# Altium schematic sheet 3_USB_TO_UART.SchDoc
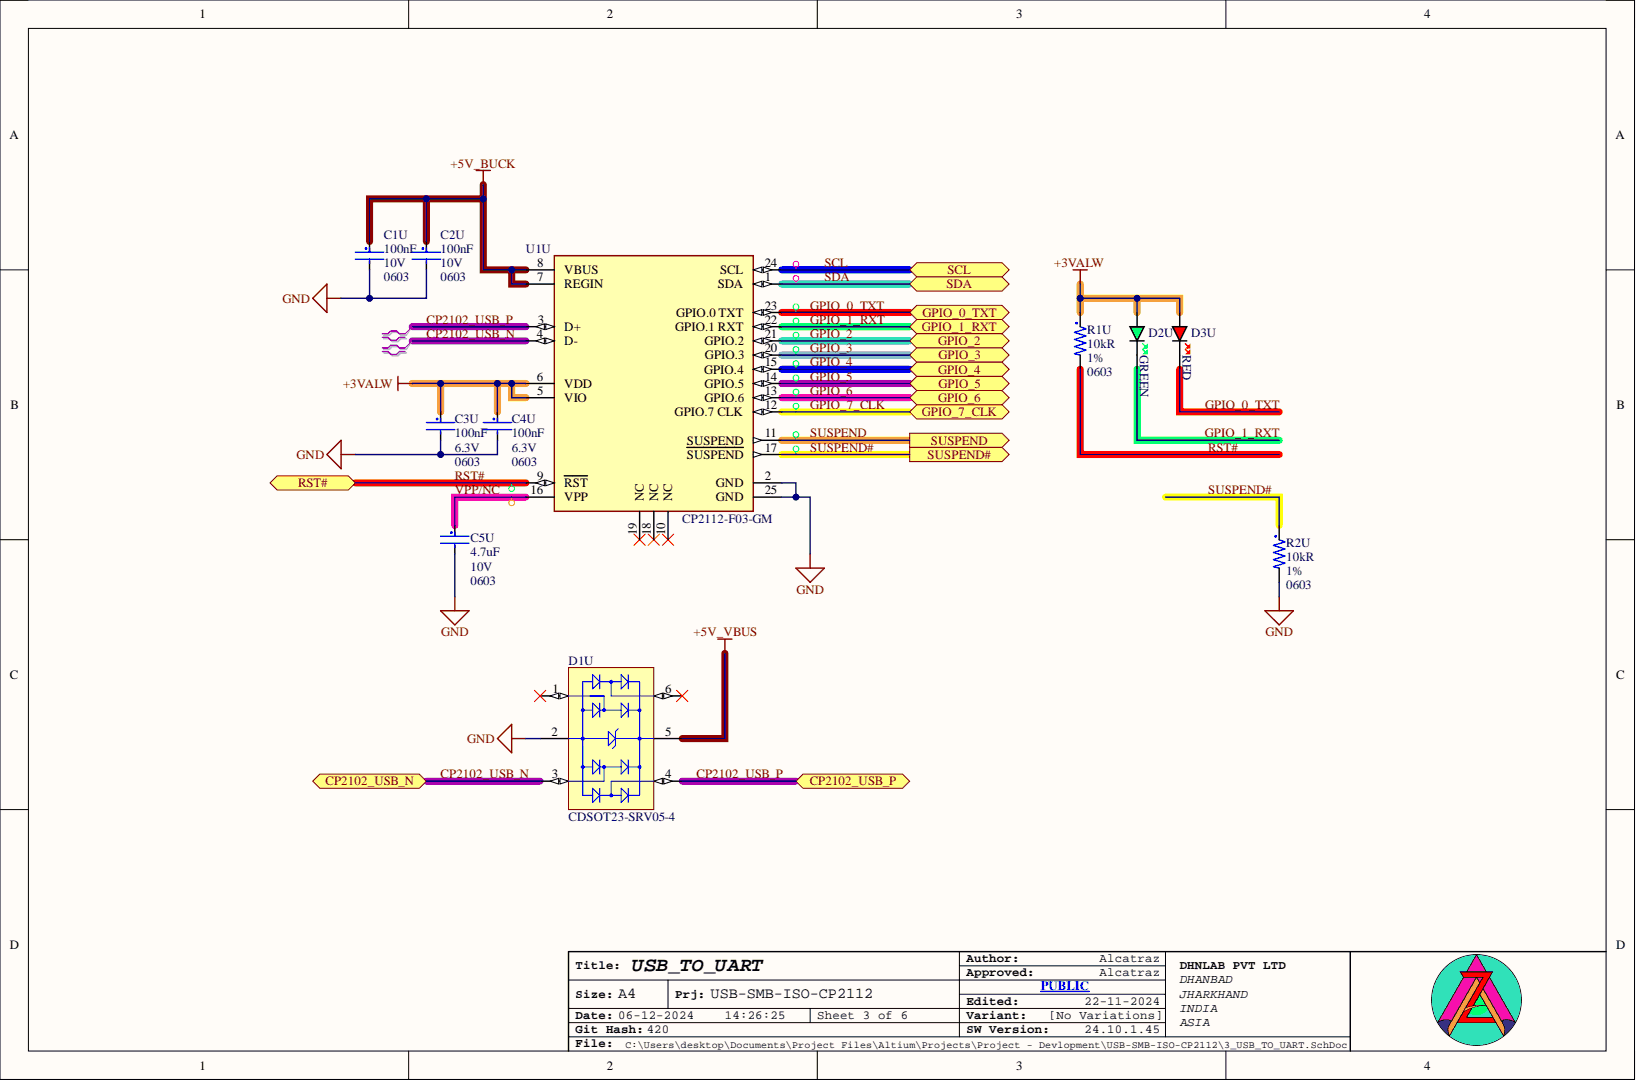
[diagram p0: 3_USB_TO_UART.SchDoc]

## Parts
C1U  value 100nF  Case Code (Imperial): 0603  Voltage: 10V  [C0603-IPC_C_No_Silk]  (hidden comment: C0603C104K8RACTU)
C2U  value 100nF  Case Code (Imperial): 0603  Voltage: 10V  [C0603-IPC_C_No_Silk]  (hidden comment: C0603C104K8RACTU)
C3U  value 100nF  Package: 0603  Voltage: 6.3V  [C0603-IPC_C_No_Silk]  (hidden comment: KGM15AR70J104KM)
C4U  value 100nF  Package: 0603  Voltage: 6.3V  [C0603-IPC_C_No_Silk]  (hidden comment: KGM15AR70J104KM)
C5U  value 4.7uF  Case Code (Imperial): 0603  Voltage Rating: 10V  [C0603-MFG_No_Silk]  (hidden comment: CL10A475KP8NNNC)
D1U  CDSOT23-SRV05-4  [SOT23-6-IPC_C]
D2U  GREEN  [LED_0603_GREEN]
D3U  RED  [LED_0603_RED]
R1U  value 10kR  Case Code (Imperial): 0603  Resistance Tolerance: 1%  [R0603-MFG]  (hidden comment: RC0603FR-0710KL)
R2U  value 10kR  Case Code (Imperial): 0603  Resistance Tolerance: 1%  [R0603-MFG]  (hidden comment: RC0603FR-0710KL)
U1U  CP2112-F03-GM  [CP2112-IPC_A]

## Ports
CP2102_USB_N, CP2102_USB_P, GPIO_0_TXT, GPIO_1_RXT, GPIO_2, GPIO_3, GPIO_4, GPIO_5, GPIO_6, GPIO_7_CLK, RST#, SCL, SDA, SUSPEND, SUSPEND#

## Nets
+3VALW: C3U.1 C4U.1 D2U.2 D3U.2 R1U.1 U1U.5(VIO) U1U.6(VDD)
+5V_BUCK: C1U.1 C2U.1 U1U.7(REGIN) U1U.8(VBUS)
+5V_VBUS: D1U.5
GND: C1U.2 C2U.2 C3U.2 C4U.2 C5U.2 D1U.2 R2U.2 U1U.2(GND) U1U.25(GND)
CP2102_USB_N: D1U.3 U1U.4(D-) ; port CP2102_USB_N
CP2102_USB_P: D1U.4 U1U.3(D+) ; port CP2102_USB_P
GPIO_0_TXT: D3U.1 U1U.23(GPIO.0_TXT) ; port GPIO_0_TXT
GPIO_1_RXT: D2U.1 U1U.22(GPIO.1_RXT) ; port GPIO_1_RXT
GPIO_2: U1U.21(GPIO.2) ; port GPIO_2
GPIO_3: U1U.20(GPIO.3) ; port GPIO_3
GPIO_4: U1U.15(GPIO.4) ; port GPIO_4
GPIO_5: U1U.14(GPIO.5) ; port GPIO_5
GPIO_6: U1U.13(GPIO.6) ; port GPIO_6
GPIO_7_CLK: U1U.12(GPIO.7_CLK) ; port GPIO_7_CLK
RST#: R1U.2 U1U.9(RST) ; port RST#
SCL: U1U.24(SCL) ; port SCL
SDA: U1U.1(SDA) ; port SDA
SUSPEND: U1U.11(SUSPEND) ; port SUSPEND
SUSPEND#: R2U.1 U1U.17(SUSPEND) ; port SUSPEND#
VPP/NC: C5U.1 U1U.16(VPP)

Not connected: D1U 1 6; U1U 10(NC) 18(NC) 19(NC)

## Library data of the parts
C1U C2U = 100nF_10V: Multilayer Ceramic Capacitor, 0.1 uF, 10 V, ± 10%, X7R, 0603 [1608 Metric]; Manufacturer: KEMET; Dissipation Factor: 5%; Material: 4241ConductorMaterial; Temperature Coefficient: 30ppm/°C; Case Code (Metric): 1608; Case/Package: 0603; Category: Ceramic Capacitors; Component Kind: Standard; ComponentLink1Description: https://datasheet.ciiva.com/6093/1776114-6093269.pdf?src-supplier=Farnell; ComponentLink1URL: https://datasheet.ciiva.com/6093/1776114-6093269.pdf?src-supplier=Farnell; ComponentLink2Description: https://datasheet.ciiva.com/7823/kem-c1002-x7r-smd-7823989.pdf?src-supplier=Element14; ComponentLink2URL: https://datasheet.ciiva.com/7823/kem-c1002-x7r-smd-7823989.pdf?src-supplier=Element14; ComponentLink3Description: https://datasheet.ciiva.com/22693/1851736-22693967.pdf?src-supplier=Element14; ComponentLink3URL: https://datasheet.ciiva.com/22693/1851736-22693967.pdf?src-supplier=Element14; ComponentLink4Description: https://datasheet.ciiva.com/14589/70095383-14589402.pdf?src-supplier=Allied+Electronics; ComponentLink4URL: https://datasheet.ciiva.com/14589/70095383-14589402.pdf?src-supplier=Allied+Electronics; ComponentLink5Description: https://datasheet.ciiva.com/14593/70095383-14593978.pdf?src-supplier=Allied+Electronics; ComponentLink5URL: https://datasheet.ciiva.com/14593/70095383-14593978.pdf?src-supplier=Allied+Electronics; ComponentLink6Description: https://datasheet.ciiva.com/5419/kem-c1002-x7r-smd-357932-5419657.pdf?src-supplier=Mouser; ComponentLink6URL: https://datasheet.ciiva.com/5419/kem-c1002-x7r-smd-357932-5419657.pdf?src-supplier=Mouser; ComponentLink7Description: https://datasheet.ciiva.com/18192/getdatasheetpartid-91529-18192711.pdf?src-supplier=Verical; ComponentLink7URL: https://datasheet.ciiva.com/18192/getdatasheetpartid-91529-18192711.pdf?src-supplier=Verical; Construction: Flat; Depth: 0.8mm; Dielectric: X7R; Dielectric Material: Ceramic; Height: 0.8mm; Lead Free: 5697LeadFree; Lead Pitch: 0.7mm; Length: 1.6mm; Library Name: Lib_Capacitor_0603.IntLib; Manufacturer Part Number: C0603C104K8RACTU; Max Operating Temperature: 125°C; Min Operating Temperature: -55°C; Mounting Technology: Surface Mount,PCB; Package Quantity: 1; Packaging: Tape and Reel; Pins: 2; Pricing 1: 1=0.11, 10=0.075, 50=0.075, 100=0.036, 1000=0.021, 10000=0.017 (USD); Radiation Hardening: No; Reach SVHC Compliant: No; RoHS: Yes; RoHS Compliant: Yes; Series: C; Stock 1: 246409; Supplier 1: Mouser; Supplier Part Number 1: 80-C0603C104K8R; Termination: SMD/SMT; Thickness: 0.034inch; Tolerance: 10%; Voltage Rating: 10V; Voltage Rating (DC): 10V; Width: 0.031inch
C3U C4U = 100nF_6.3V: Cap Cer 0.1UF 6.3V X7R 0603; Manufacturer: KYOCERA AVX; Tol: 10%; Category: Ceramic Capacitors; Component Kind: Standard; Library Name: Lib_Capacitor_0603.IntLib; Manufacturer Part Number: KGM15AR70J104KM; Pricing 1: 1=0.1, 10=0.032, 50=0.0186, 100=0.0144, 500=0.01082, 1000=0.0085, 2500=0.00772, 5000=0.00711 (USD); Stock 1: 117167; Supplier 1: Digikey; Supplier Part Number 1: 478-KGM15AR70J104KMCT-ND
C5U = 4.7uF_10V: Multilayer Ceramic Capacitor, 4.7 uF, 10 V, ± 10%, X5R, 0603 [1608 Metric]; Manufacturer: Samsung; Case Code (Metric): 1608; Case/Package: 0603; Category: Ceramic Capacitors; Component Kind: Standard; Construction: Flat; Depth: 0.8mm; Dielectric: X5R; Height: 0.8mm; Length: 1.6mm; Library Name: Lib_Capacitor_0603.IntLib; Manufacturer Part Number: CL10A475KP8NNNC; Max Operating Temperature: 85°C; Min Operating Temperature: -55°C; Mounting Technology: Surface Mount; Package Quantity: 1; Packaging: Tape and Reel; Pricing 1: 4000=0.0042 (USD); Radiation Hardening: No; RoHS: Yes; RoHS Compliant: Yes; Series: CL; Stock 1: 412000; Supplier 1: Verical; Supplier Part Number 1: CL10A475KP8NNNC; Thickness: 0.035inch; Tolerance: 10%; Voltage Rating (DC): 10V; Width: 0.031inch
D1U = SRV05-4: TVS DIODE 5V 15V SOT23-6; Manufacturer: Bourns Inc.; Breakdown Voltage: 6V; Direction: Bidirectional; Max Junction Temp: +150°C; Number Of Channels: 4; Peak Pulse Current: 30A; Peak Pulse Power: 500W; Standoff Height: 0.2mm; Automotive: No; Category: Diode; Clamping Voltage: 15V; Component Kind: Standard; ComponentLink2Description: Datasheet; ComponentLink2URL: https://www.bourns.com/docs/Product-Datasheets/CDSOT23-SRV05-4.pdf; Device Class L1: Circuit Protection; Device Class L2: TVS Diodes; Device Class L3: unset; Digikey Description: TVS DIODE 5V 15V SOT23-6; Height: 1.45mm; Ipc Land Pattern Name: SOT95P280X118-6; Lead Free: Yes; Library Name: Lib_IC_ESD.IntLib; Manufacturer Part Number: CDSOT23-SRV05-4; Mouser Description: CDSOT23-SRV05-4, Quad Uni-Directional TVS Diode, 500W, 6-Pin SOT-23; Octopart: https://octopart.com/cdsot23-srv05-4-bourns-1679449; Package: CDSOT23-SRV05-4; Reverse Standoff Voltage: 5V; RoHS: TRUE; Temperature: -55°C to +150°C; Temperature Range High: +150°C; Temperature Range Low: -55°C
D2U = LED_0603_GREEN: LED 0603 GREEN SMD; Component Kind: Standard; Library Name: Lib_LED.IntLib
D3U = LED_0603_RED: LED 0603 RED SMD; Component Kind: Standard; Library Name: Lib_LED.IntLib
R1U R2U = 10K: SMD Chip Resistor, 10 kOhm, ± 1%, 100 mW, 0603 [1608 Metric], Thick Film, General Purpose; Manufacturer: Yageo; Max Power Dissipation: 100mW; Temperature Coefficient: 100ppm/°C; Voltage Rating: 75V; Voltage Rating (DC): 50V; Case Code (Metric): 1608; Case/Package: 0603; Category: Chip SMD Resistors; Component Kind: Standard; Construction: Rectangular; Depth: 0.8mm; Features: Moisture Resistant; Height: 0.45mm; Lead Free: 5697LeadFree; Lead Pitch: 0.3mm; Length: 1.6mm; Library Name: Lib_Register_0603.IntLib; Manufacturer Part Number: RC0603FR-0710KL; Max Operating Temperature: 155°C; Military Standard: Not; Min Operating Temperature: -55°C; Number of Terminations: 2; Packaging: Tape and Reel; Pins: 2; Power Rating: 100mW; Pricing 1: 5000=0.0015, 50000=0.0014, 150000=0.0014, 375000=0.0013, 1000000=0.0012 (USD); Reach SVHC Compliant: No; Resistor Type: Thick Film; RoHS: Yes; RoHS Compliant: Yes; Series: RC; Stock 1: 44660000; Supplier 1: Future Electronics; Supplier Part Number 1: 4023214; Termination: SMD/SMT; Tolerance: 1%; Weight: 7.1E-05oz; Width: 0.8mm
U1U = CP2112-F03-GM: IC HID USB-TO-SMBUS BRIDGE 24QFN; Manufacturer: Silicon Labs; Interface: USB,SMBus; Max Junction Temp: +105°C; Max Supply Voltage: 3.6V; Min Supply Voltage: 1.8V; Nominal Supply Current: 130-1500uA; Standoff Height: 0mm; Ambient Temperature Range High: +85°C; Ambient Temperature Range Low: -40°C; Automotive: No; Category: IC; Component Kind: Standard; ComponentLink2Description: Datasheet; ComponentLink2URL: https://www.silabs.com/documents/public/data-sheets/cp2112-datasheet.pdf; Device Class L1: Integrated Circuits (ICs); Device Class L2: Interface ICs; Device Class L3: Controllers; Digikey Description: IC HID USB-TO-SMBUS BRIDGE 24QFN; Height: 0.8mm; Ipc Land Pattern Name: QFN50P400X400X75-24; Lead Free: Yes; Library Name: Lib_IC_UART.IntLib; Manufacturer Part Number: CP2112-F03-GM; Mouser Description: Single-Chip HID USB to SMBus Master Bridge 24-Pin QFN; Octopart: https://octopart.com/cp2112-f03-gm-silicon+labs-81780828; Package: CP2112-F03-GM; RoHS: TRUE; Temperature: -40°C to +85°C; Temperature Range High: +85°C; Temperature Range Low: -40°C
